# Altium schematic sheet Battery Heating System.SchDoc
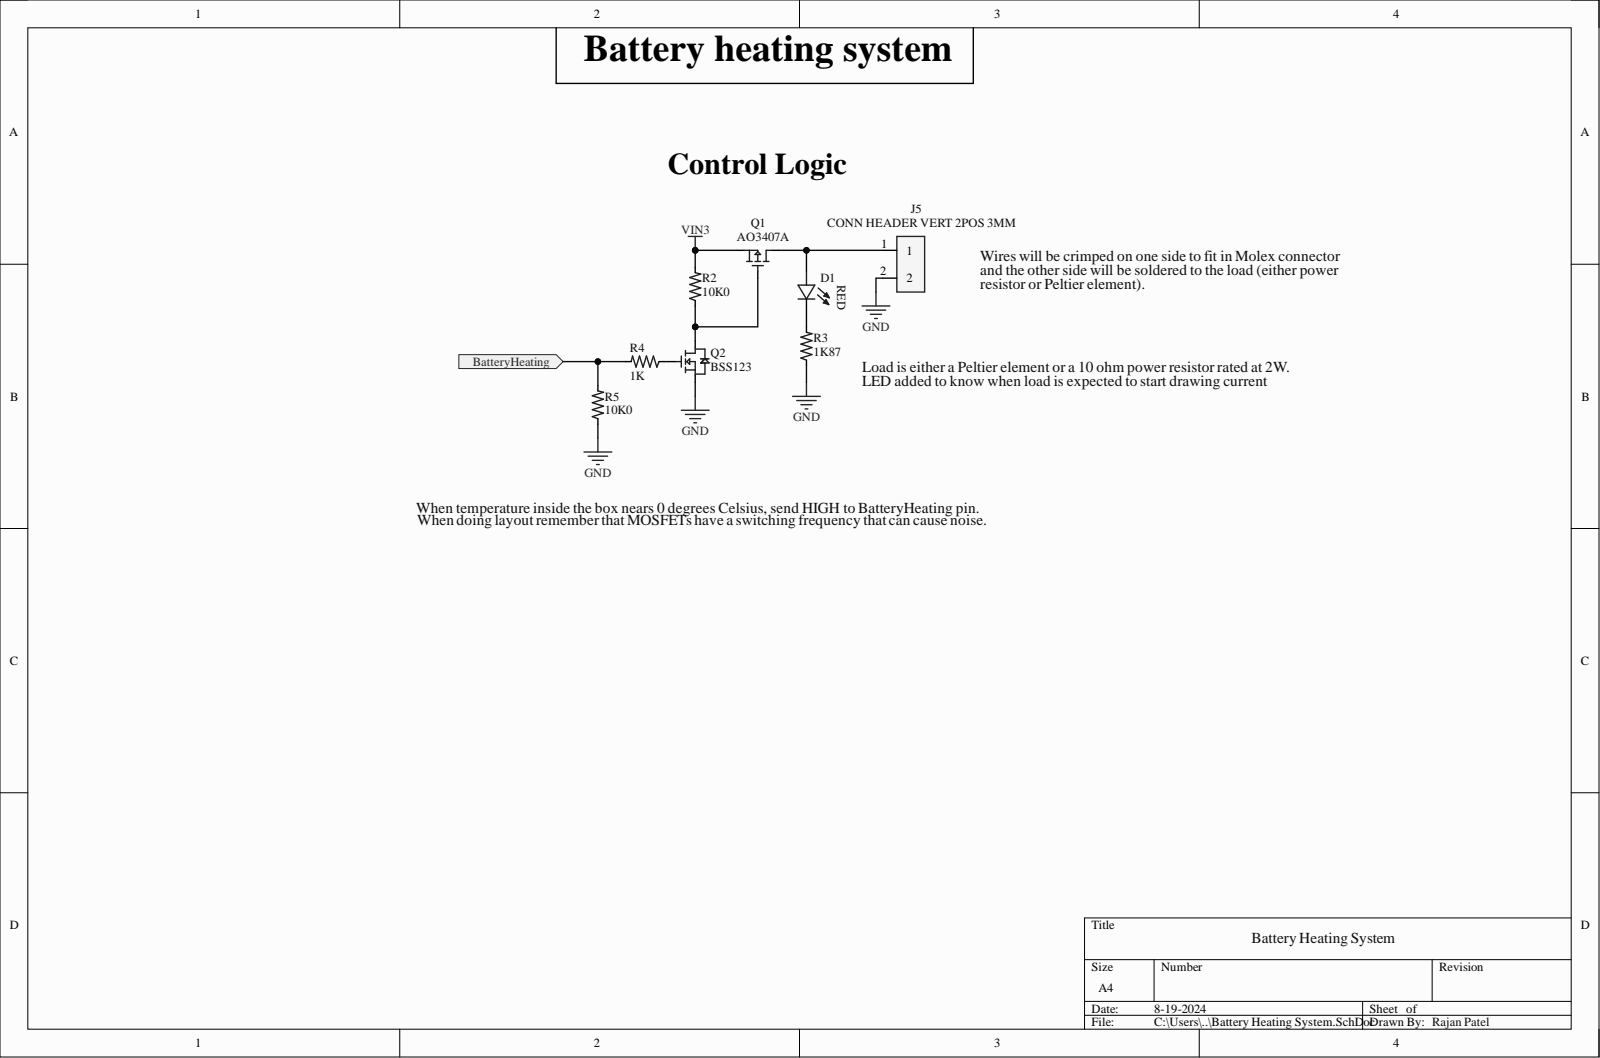
[diagram p0: Battery Heating System.SchDoc]

## Parts
D1  LED 0805  [LED_0805]  (value not drawn)
J5  CONN HEADER VERT 2POS 3MM  [CONN HEADER VERT 2POS 3MM]
Q1  AO3407A  [AO3407A]
Q2  BSS123  [BSS123]
R2  10K0  [res 0805]
R3  1K87  [res 0805]
R4  1K  [res 0805]
R5  10K0  [res 0805]

## Ports
BatteryHeating

## Nets
GND: J5.2 Q2.2 R3.1 R5.1
VIN3: Q1.2 R2.2
BatteryHeating: R4.2 R5.2 ; port BatteryHeating
NetD1_1: D1.1 R3.2
NetD1_2: D1.2 J5.1 Q1.3
NetQ1_1: Q1.1 Q2.3 R2.1
NetQ2_1: Q2.1 R4.1

## Library data of the parts
D1 = LED 0805: Red LED Indication - Discrete 1.7V 0805 (2012 Metric); MP: 7012X1; Availability: Unavailable; Component Kind: Standard; Package: None; Price: None; Library Name: Payload schlib.SchLib
J5 = 0436500228: Rectangular Power Connector, 2 Contact(s), Female, Solder Terminal; Manufacturer: Molex; Manufacturer Part Number: 43650-0228; Manufacturer Series: 43650; Board Mounting Option: PEG; Body Breadth: 0.219inch; Body Depth: 0.39inch; Body Length: 0.38inch; Body/Shell Style: RECEPTACLE; Connector Type: Power,Rectangular; Contact Resistance: 10mR; Contact Style: SQ PIN-SKT; Dielectric Withstanding Voltage (AC): 1.5kV; DIN Conformance: No; Durability: 30Cycles; Insertion Force-Max: 8.0064N; Insulation Resistance: 1GR; Mating Contact Pitch: 0.118inch; Mating Information: MULTIPLE MATING PARTS AVAILABLE; Mounting Option 1: POLARIZED LCK; Mounting Option 2: LOCKING; MP: 0436500200; Number Of Connectors: 1; Number of Contacts: 2; Option: General Purpose; Plating Thickness: 15uin; Polarization Key: POLARIZED HOUSING; Rated Current (Signal): 5A; Reference Standard: UL; Reliability: COMMERCIAL; Withdrawl Force-Min: 3.614N; Availability: Unavailable; Category: Headers and Wire Housings; Component Kind: Standard; ComponentLink1Description: https://datasheet.ciiva.com:443/pdfs/VipMasterIC/IC/MOLE/MOLES07498/MOLES07498-1.pdf?src-supplier=IHS+Markit; ComponentLink1URL: https://datasheet.ciiva.com:443/pdfs/VipMasterIC/IC/MOLE/MOLES07498/MOLES07498-1.pdf?src-supplier=IHS+Markit; ComponentLink2Description: https://datasheet.ciiva.com/13550/0436500228-pcb-headers-148884-13550297.pdf?src-supplier=Mouser; ComponentLink2URL: https://datasheet.ciiva.com/13550/0436500228-pcb-headers-148884-13550297.pdf?src-supplier=Mouser; Contact Finish - Mating: AU; Contact Finish - Termination: TIN/LEAD OVER NICKEL; Contact Gender: Male; Contact Material: Brass; Contact Pattern: RECTANGULAR; Empty Shell: No; Filter Feature: No; Flammability Rating: UL94 V-0; IEC Conformance: No; Insulation Color: Black; Insulation Material: Polymer; Max Operating Temperature: 105°C; MF: Molex; MIL Conformance: No; Min Operating Temperature: -40°C; Mixed Contacts: No; Mounting Style: STRAIGHT; Mounting Technology: Board; Number Of PCB Rows: 1; Number of Rows Loaded: 1; Package: None; PCB Contact Pattern: RECTANGULAR; Price: None; Pricing: 1=0.78, 10=0.537, 50=0.537, 100=0.537, 1000=0.536, 10000=0.473 (USD); Reach SVHC Compliant: Yes; RoHS: Yes; RoHS Compliant: Yes; Shell Material: Polymer; SnapEDA_Link: https://www.snapeda.com/parts/0436500200/Molex/view-part/552556/?ref=snap; Stock: 12783; Supplier: Mouser; Supplier Part Number: 538-43650-0228; Terminal Length: 0.125inch; Terminal Pitch: 2.9972mm; Termination: Solder; Library Name: Payload schlib.SchLib
Q1 = AO3407A: Small Signal Field-Effect Transistor, 4.3A I(D), 30V, 1-Element, P-Channel, Silicon, Metal-oxide Semiconductor FET; Manufacturer: Alpha & Omega Semiconductor; Drain-source On Resistance-Max: 48mR; Feedback Cap-Max (Crss): 90pF; Number of Elements: 1; Operating Mode: ENHANCEMENT MODE; Package Body Material: Plastic; Power Dissipation-Max (Abs): 1.4W; Qualification Status: Not Qualified; Transistor Application: SWITCHING; Category: MOSFETs; Component Kind: Standard; ComponentLink1Description: https://datasheet.ciiva.com:443/pdfs/VipMasterIC/IC/ALOM/ALOMS01464/ALOMS01464-1.pdf?src-supplier=IHS+Markit; ComponentLink1URL: https://datasheet.ciiva.com:443/pdfs/VipMasterIC/IC/ALOM/ALOMS01464/ALOMS01464-1.pdf?src-supplier=IHS+Markit; ComponentLink2Description: https://datasheet.ciiva.com/940/ao3407a-940745.pdf?src-supplier=Digikey; ComponentLink2URL: https://datasheet.ciiva.com/940/ao3407a-940745.pdf?src-supplier=Digikey; ComponentLink3Description: https://datasheet.ciiva.com/940/ao3407a-940747.pdf?src-supplier=Digikey; ComponentLink3URL: https://datasheet.ciiva.com/940/ao3407a-940747.pdf?src-supplier=Digikey; ComponentLink4Description: https://datasheet.ciiva.com/18057/getdatasheetpartid-64436-18057110.pdf?src-supplier=Verical; ComponentLink4URL: https://datasheet.ciiva.com/18057/getdatasheetpartid-64436-18057110.pdf?src-supplier=Verical; Drain Current-Max (Abs) (ID): 4.3A; Drain Current-Max (ID): 4.3A; DS Breakdown Voltage-Min: 30V; FET Technology: METAL-OXIDE SEMICONDUCTOR; JESD-30 Code: R-PDSO-G3; JESD-609 Code: e3; Manufacturer Part Number: AO3407A; Max Operating Temperature: 150°C; Moisture Sensitivity Level: 1; Package Shape: Rectangular; Package Style: SMALL OUTLINEMeter; Pins: 3; Polarity/Channel Type: P-CHANNEL; Pricing: 1=0.31, 10=0.22, 100=0.1112, 500=0.09854, 1000=0.07668 (USD); Reach SVHC Compliant: Yes; RoHS: Yes; RoHS Compliant: Yes; Stock: 856157; Supplier: Digikey; Supplier Part Number: 785-1006-1-ND; Surface Mount: Yes; Terminal Finish: Tin; Terminal Form: Gull Wing; Terminal Position: DUAL; Transistor Element Material: SILICON; Library Name: Payload schlib.SchLib
Q2 = BSS123_1: Small Signal Field-Effect Transistor, 0.17A I(D), 100V, 1-Element, N-Channel, Silicon, Metal-oxide Semiconductor FET, TO-236; Manufacturer: ON Semiconductor / Fairchild; Drain Current-Max (Abs) (ID): 170mA; Drain-source On Resistance-Max: 10R; Feedback Cap-Max (Crss): 6pF; Number of Elements: 1; Operating Mode: ENHANCEMENT MODE; Package Body Material: Plastic; Power Dissipation-Max (Abs): 360mW; Qualification Status: Not Qualified; Transistor Application: SWITCHING; Category: MOSFETs; Component Kind: Standard; ComponentLink1Description: https://datasheet.ciiva.com:443/pdfs/VipMasterIC/IC/ONSM/ONSM-S-A0003158648/ONSM-S-A0003544094-1.pdf?src-supplier=IHS+Markit; ComponentLink1URL: https://datasheet.ciiva.com:443/pdfs/VipMasterIC/IC/ONSM/ONSM-S-A0003158648/ONSM-S-A0003544094-1.pdf?src-supplier=IHS+Markit; Drain Current-Max (ID): 170mA; DS Breakdown Voltage-Min: 100V; FET Technology: METAL-OXIDE SEMICONDUCTOR; JESD-30 Code: R-PDSO-G3; Manufacturer Part Number: BSS123; Max Operating Temperature: 150°C; Moisture Sensitivity Level: 1; Package Shape: Rectangular; Package Style: SMALL OUTLINEMeter; Pins: 3; Polarity/Channel Type: N-CHANNEL; Pricing: 1=0.35, 10=0.246, 100=0.1244, 500=0.10144, 1000=0.07527 (USD); Reach SVHC Compliant: Yes; RoHS: Yes; RoHS Compliant: Yes; Stock: 15036; Supplier: Digikey; Supplier Part Number: BSS123NCT-ND; Surface Mount: Yes; Terminal Form: Gull Wing; Terminal Position: DUAL; Transistor Element Material: SILICON; Library Name: Payload schlib.SchLib
R2-R5 = Resistor 0805: MF: TE Connectivity; MP: 2-2176053-2; Power_Rating: .25 W; Resistance: .39; Tolerance: 1%; Availability: Unavailable; Component Kind: Standard; EU_RoHS_Compliance: Compliant with Exemptions; Package: 0805; Price: None; SnapEDA_Link: https://www.snapeda.com/parts/2-2176053-2/TE%20Connectivity/view-part/2214511/?ref=snap; Library Name: Payload schlib.SchLib
